# Altium schematic sheet Stage3E_power_ORing.SchDoc
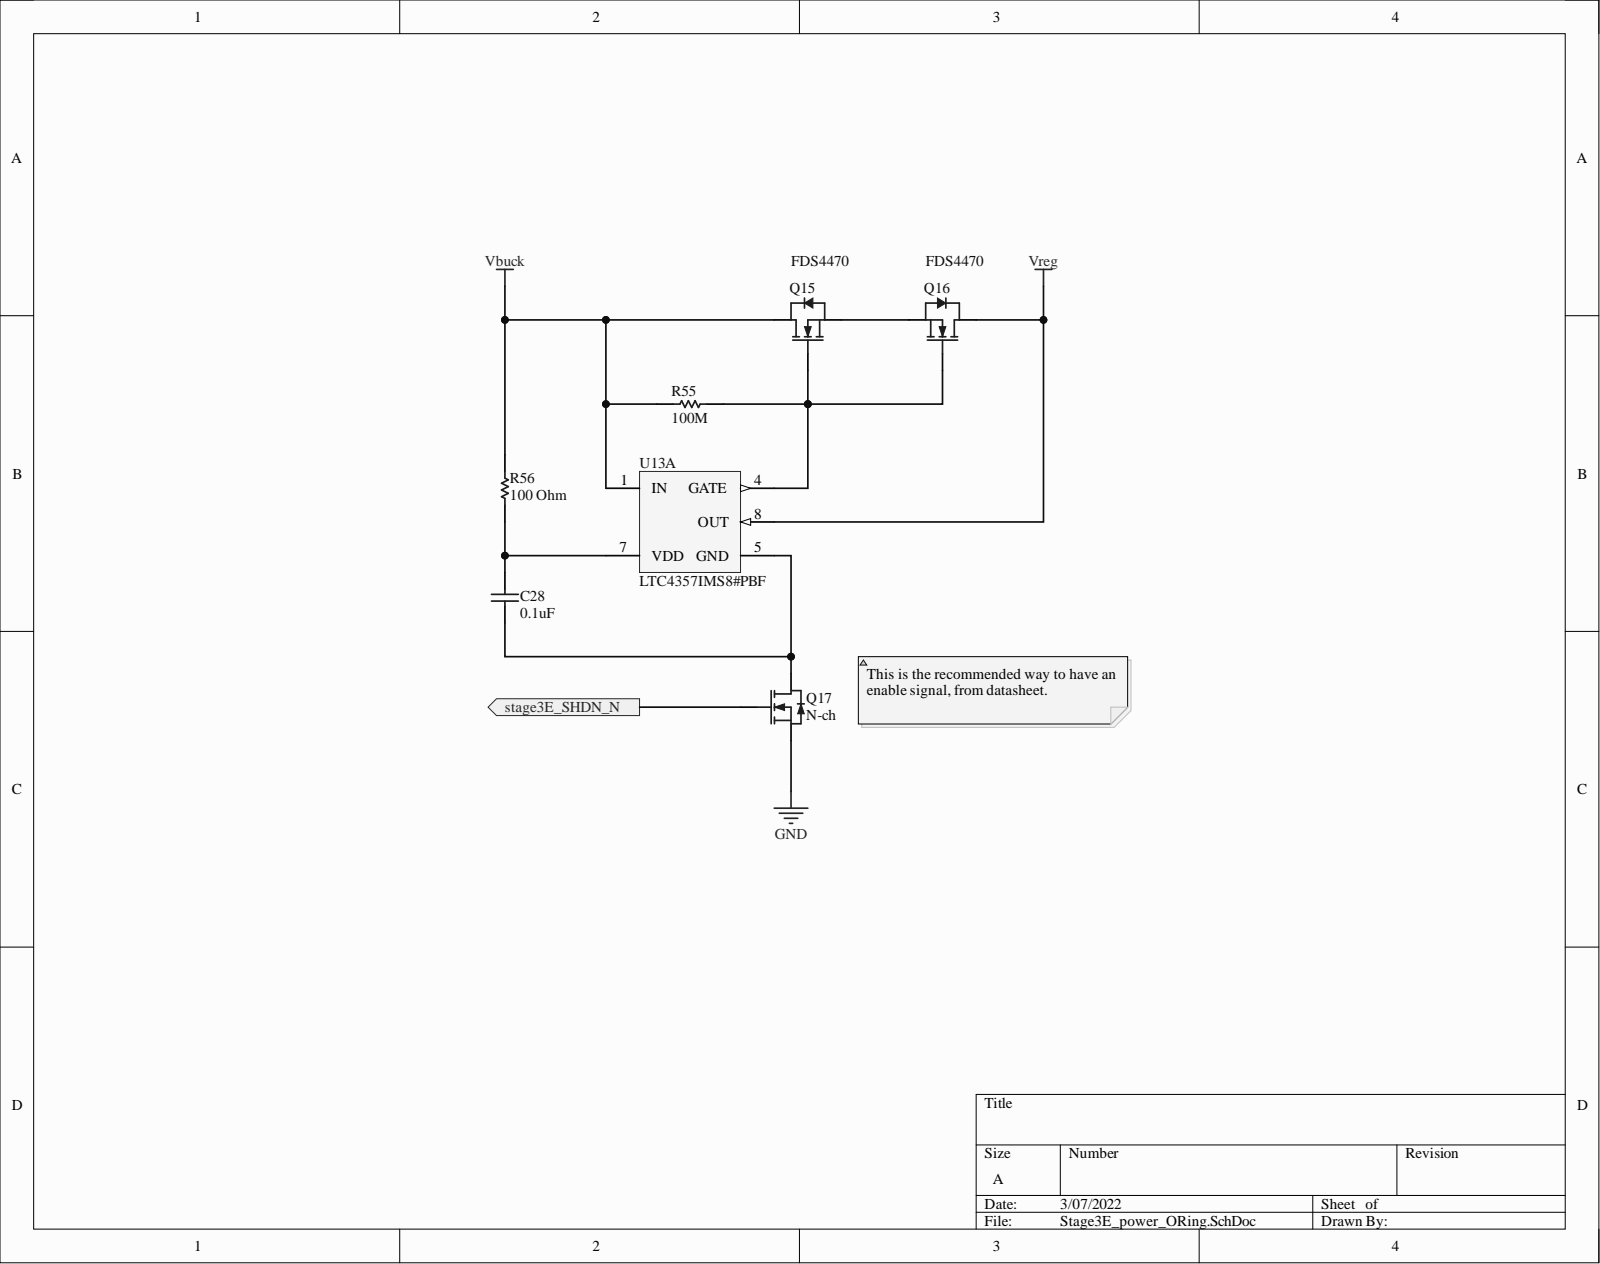
[diagram p0: Stage3E_power_ORing.SchDoc]

## Parts
C28  0.1uF  [PCB-0002-00735-1]
Q15  FDS4470  [PCB-vam1no1ldi8601k0q85a-1]
Q16  FDS4470  [PCB-vam1no1ldi8601k0q85a-1]
Q17  N-ch  [PCB-6ki0nua8twa8bgk8z1dw-1]
R55  100M  [RESC2013X50X30NL20T20]
R56  100 Ohm  [PCB-0002-00380-8]
U13  LTC4357IMS8#PBF  [LT-MS8-8_L]  (part A)

## Ports
stage3E_SHDN_N

## Nets
GND: Q17.2
Vbuck: Q15.3 R55.1 R56.2 U13.1(IN)
Vreg: Q16.3 U13.8(OUT)
stage3E_SHDN_N: Q17.1 ; port stage3E_SHDN_N
NetC28_1: C28.1 Q17.3 U13.5(GND)
NetC28_2: C28.2 R56.1 U13.7(VDD)
NetQ15_1: Q15.1 Q16.1 R55.2 U13.4(GATE)
NetQ15_2: Q15.2 Q16.2

## Library data of the parts
C28 = CMP-126393-3: CAP CER 0.1UF 50V 10% X7R 0805; Manufacturer Part Number: 08055C104KAZ2A; Capacitance: 1e-07 F; Case/Package (SI): 2012; Dielectric Material: Ceramic Multilayer; Lead-Free Status: Lead Free; Voltage Rating (DC): 50.0 V; Capacitance Tolerance: ±10%; Case/Package: 0805; Component Kind: Standard; Dielectric Characteristic: X7R; Library Name: My Personal Space; Mounting Style: Surface Mount; Number of Pins: 2; Octopart Url: https://octopart.com/08055c104kaz2a-avx+interconnect+%2F+elco-195624; OctopartID: aa35633d737b75f2; REACH SVHC Compliance: No SVHC; RoHS: Compliant; Size-Height: 0.00094 m; Size-Length: 0.00201 m; Size-Width: 0.00125 m
Q15 Q16 = CMP-cab003bfccd71577-2: FDS4470 Series 40 V 9 mOhm Surface Mount N-Channel PowerTrench Mosfet - SOIC-8; Breakdown Voltage (Drain to Source): -100.0 V; Continuous Drain Current (Ids): 12.5 A; Drain to Source Resistance (on) (Rds): 0.009 Ω; Drain to Source Voltage (Vds): 40.0 V; Gate Charge: 4.5e-08 C; Input Capacitance: 2.659e-09 F; Lead-Free Status: Lead Free; Power Dissipation: 2.5 W; Rise Time: 1.2e-08 s; Case/Package: SOIC; Component Kind: Standard; Current Rating: 12.5 A; Library Name: My Personal Space; Lifecycle Status: Active; Manufacturer Part Number: FDS4470; Mounting Style: Surface Mount; Number of Pins: 8; Octopart URL: http://octopart.com/fds4470-fairchild+semiconductor-336641; OctopartID: cab003bfccd71577; Packaging: Tape; Polarity: N-Channel; REACH SVHC Compliance: No SVHC; RoHS: Compliant; Voltage Rating (DC): 40.0 V
Q17 = CMP-bbed7695b02f642a-2: Trans MOSFET N-CH 60V 0.19A 3-Pin TO-236AB T/R; Manufacturer: Nexperia USA Inc.; Manufacturer Part Number: NX138AKR; Lead-Free Status: Lead Free; Case/Package: SOT-23; Component Kind: Standard; Library Name: My Personal Space; Lifecycle Status: Active; Octopart URL: https://octopart.com/nx138akr-nxp+semiconductors-74591908; OctopartID: bbed7695b02f642a; RoHS: Compliant; Supplier: Digi-Key; Supplier Part Number: 1727-2731-2-ND
R55 = CMP-3652503-2: Thick Film Resistors - SMD 1/8watt 100Mohms 5% 500ppm; Manufacturer Part Number: CRCW0805100MJPEAHR; Lead-Free Status: Lead Free; Power Rating: 0.125 W; Voltage Rating (DC): 150.0 V; Component Kind: Standard; Library Name: My Personal Space; Number of Pins: 2; Octopart Url: https://octopart.com/crcw0805100mjpeahr-vishay-42854217; OctopartID: e1e62a6123c93e21; Packaging: Cut Tape (CT); REACH SVHC Compliance: No SVHC; Resistance Tolerance: ±5%; RoHS: Compliant
R56 = CMP-1854308-9: RES SMD 100 OHM 1% 1/8W 0805; Component Kind: Standard; Library Name: My Personal Space
U13 = CMP-979297-2: Positive High Voltage Ideal Diode Controller, 9 to 80 V Vin, 8-pin SOP (MS8-8), -40 to 85 degC, Pb-Free; Lead-Free Status: Lead Free; Number of Outputs: 1; Output Current: 8e-05 A; Output Voltage: 80.0 V; Component Kind: Standard; Library Name: My Personal Space; Manufacturer Part Number: LTC4357IMS8#PBF; Mounting Style: Surface Mount; Number of Pins: 8; Octopart Url: https://octopart.com/ltc4357ims8%23pbf-linear+technology-7502457; OctopartID: cc4e053693511389; REACH SVHC Compliance: No SVHC; RoHS: Compliant
